# Altium schematic sheet Notch Filter.SchDoc("Notch Filter")
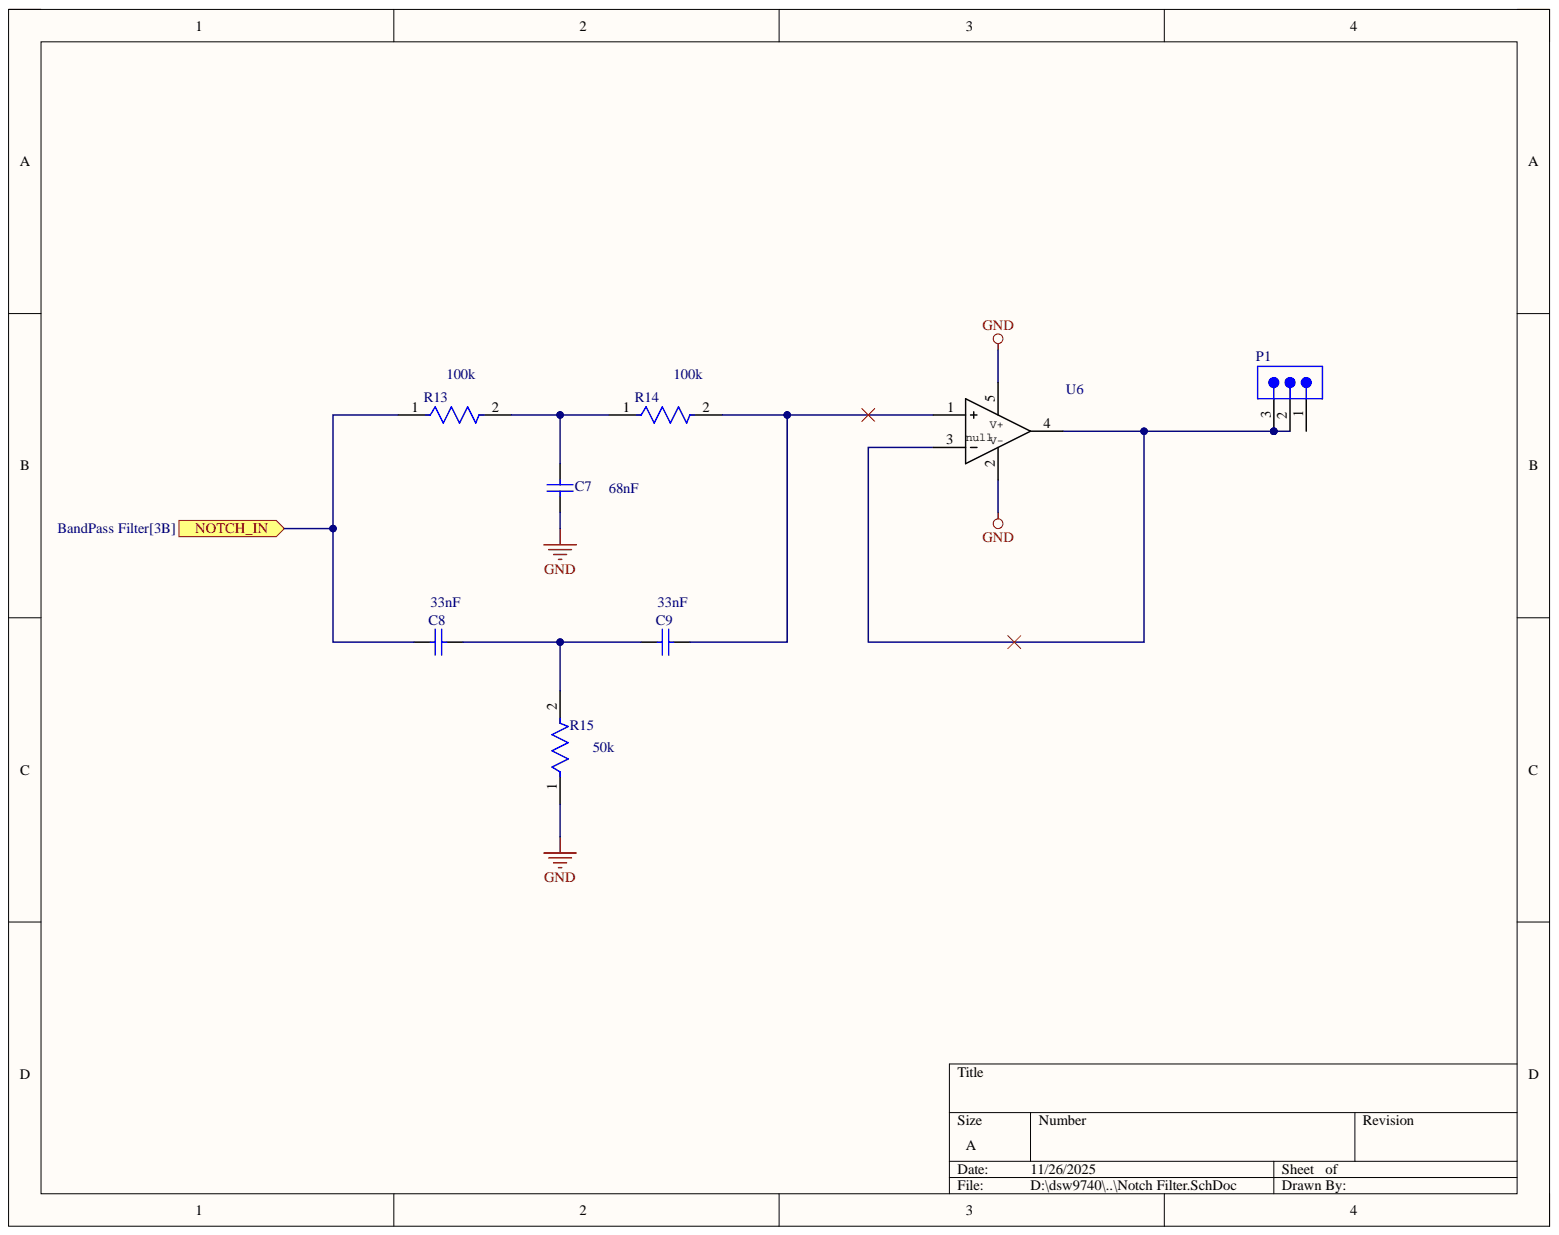
[diagram p0: Notch Filter.SchDoc]

## Parts
C7  C0805C225K9PACTU  [CAPC2013X145X50ML20T25]  (value not drawn)
C8  C0805C225K9PACTU  [CAPC2013X145X50ML20T25]  (value not drawn)
C9  C0805C225K9PACTU  [CAPC2013X145X50ML20T25]  (value not drawn)
P1  TSW-103-07-F-S  [SMTC-TSW-103-07-X-S]  (value not drawn)
R13  CRCW080516R0FKEA  [FP-CRCW0805-e3-MFG]  (value not drawn)
R14  CRCW080516R0FKEA  [FP-CRCW0805-e3-MFG]  (value not drawn)
R15  CRCW080516R0FKEA  [FP-CRCW0805-e3-MFG]  (value not drawn)
U6  TLV9041IDCKR  [DCK0005A-IPC_A]  (value not drawn)

## Ports
NOTCH_IN

## Nets
GND: C7.1 R15.1 U6.2(V-) U6.5(V+)
NOTCH_IN: C8.2 R13.1 ; port NOTCH_IN
NetC7_2: C7.2 R13.2 R14.1
NetC8_1: C8.1 C9.2 R15.2
NetC9_1: C9.1 R14.2 U6.1
NetP1_2: P1.2 P1.3 U6.3 U6.4

Not connected: P1 1

## Library data of the parts
C7-C9 = CMP-1036-04819-2: Manufacturer: Kemet; Component Kind: Standard; Library Name: Altium Content Vault; Part Number: C0805C225K9PACTU
P1 = CMP-1024-00331-1: 0.025" SQ Post Header, Through-hole, Vertical, -55 to 125 degC, 2.54 mm Pitch, 3-Pin, Male, RoHS; Manufacturer: Samtec; Pitch: 2.54 mm; Component Kind: Standard; ComponentLink1Description: Manufacturer URL; ComponentLink1URL: http://www.samtec.com; ComponentLink2Description: Datasheet; ComponentLink2URL: http://www.samtec.com/documents/webfiles/pdf/TSW_TH.PDF; ComponentLink3Description: Package Specification; ComponentLink3URL: http://www.samtec.com/documents/webfiles/cpdf/TSW-XXX-XX-XXX-X-XX-XXX-MKT.pdf; DatasheetVersion: F-214; Library Name: Altium Content Vault; Mounting Technology: Through-hole; Orientation: Vertical; PackageDescription: .025[0.64] SQ. Post Terminal Strip Assembly; PackageReference: TSW-103-07-X-S; PackageVersion: DM, 8/1988; PartNumber: TSW-103-07-F-S; RoHS: True; Temperature Range: -55 to 125 degC
R13-R15 = CMP-02407-002795-1: RES Thick Film, 16Ω, 1%, 0.125W, 100ppm/°C, 0805; Max Power Dissipation: 125mW; Resistance: 16R; Voltage Rating: 150V; Case Code (Imperial): 0805; Case Code (Metric): 2012; Case/Package: 0805; Component Kind: Standard; Composition: Thick Film; Contact Plating: Tin; Depth: 1.25mm; Features: Automotive AEC-Q200; Height: 0.02inch; Lead Free: Lead Free; Length: 2mm; Library Name: Altium Content Vault; Max Operating Temperature: 155°C; Military Standard: Not; Min Operating Temperature: -55°C; Number of Pins: 2; Number of Terminations: 2; Package Quantity: 10; Packaging: Tape and Reel; Power Rating: 125mW; Radiation Hardening: No; Temperature Coefficient: 100ppm/°C; Termination: SMD/SMT; Tolerance: 1%; Voltage Rating (DC): 150V; Weight: 0.000194oz; Width: 0.049inch
U6 = TLV9041IDCKR: Single, 5.5-V, 350-kHz, ultra-low 1.2-V, low-quiescent current (10-Î¼A) op amp 5-SC70 -40 to 125; Manufacturer_Name: Texas Instruments; Component Kind: Standard; Library Name: TLV9041IDCKR.SchLib; Manufacturer_Part_Number: TLV9041IDCKR; RefDes: RefDes; TYPE: TYPE; Copyright: Copyright (C) 2025 Ultra Librarian. All rights reserved.
